# Altium schematic sheet [00] - Top Sheet.SchDoc
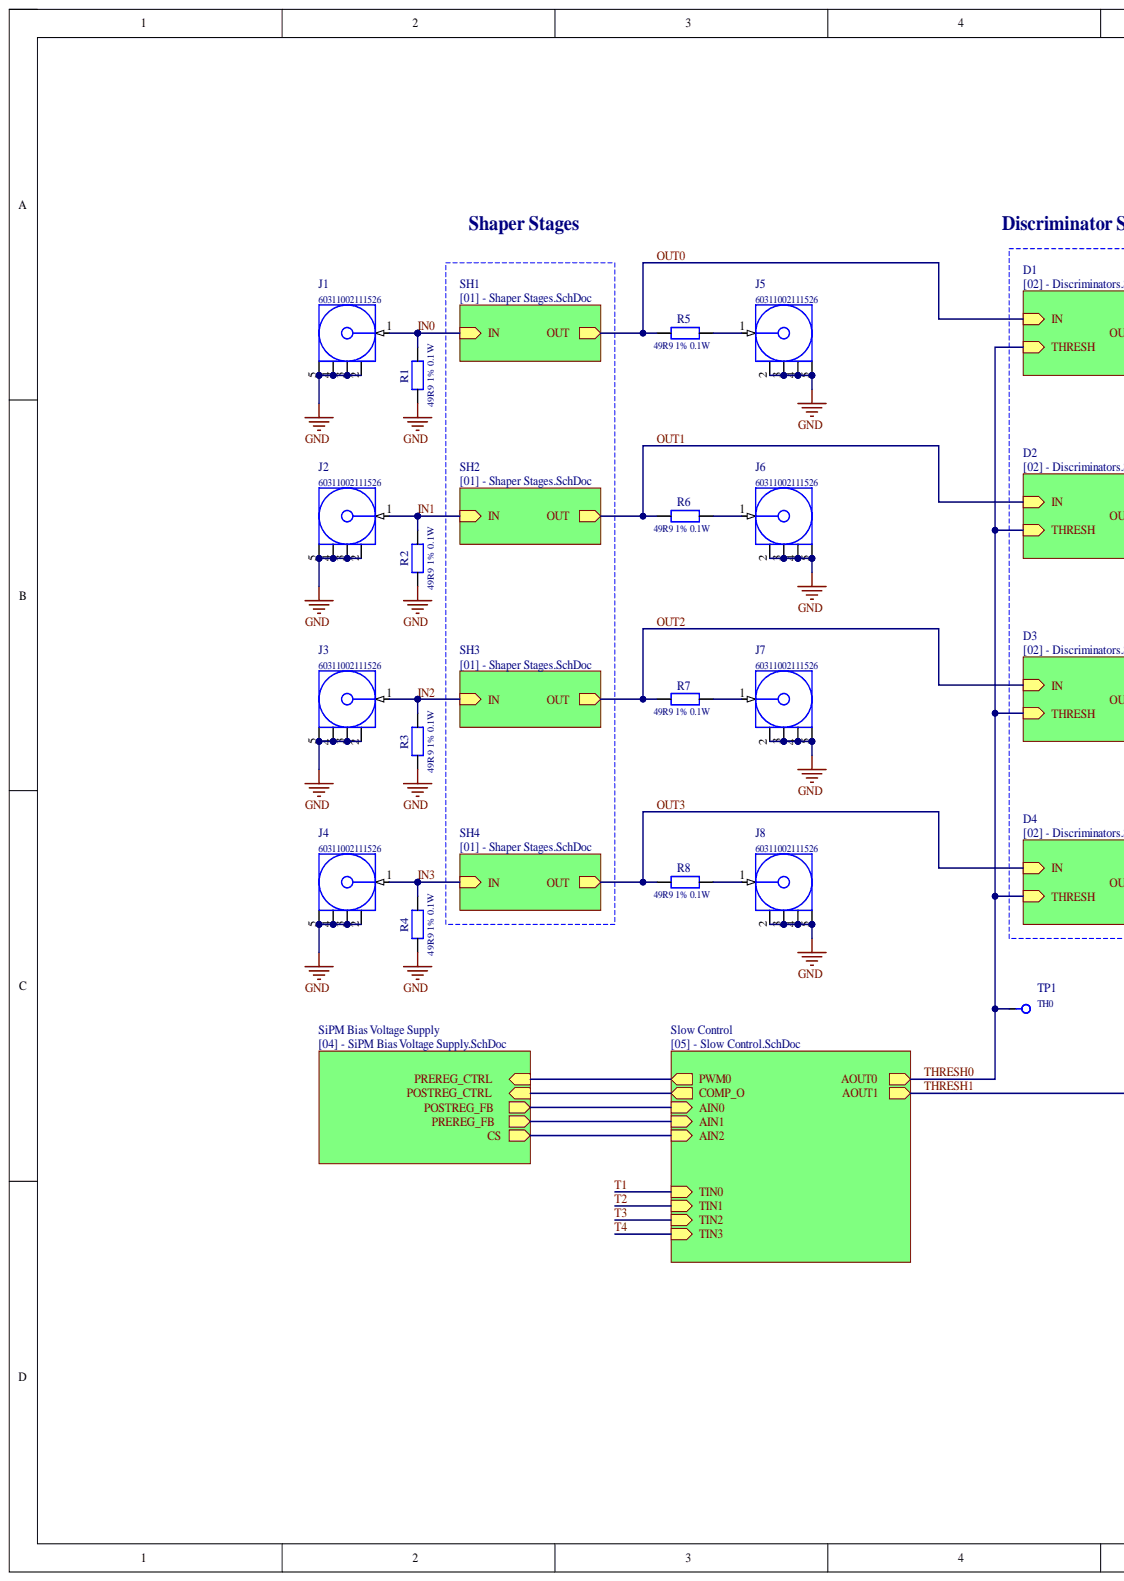
[diagram p0: [00] - Top Sheet.SchDoc, left]
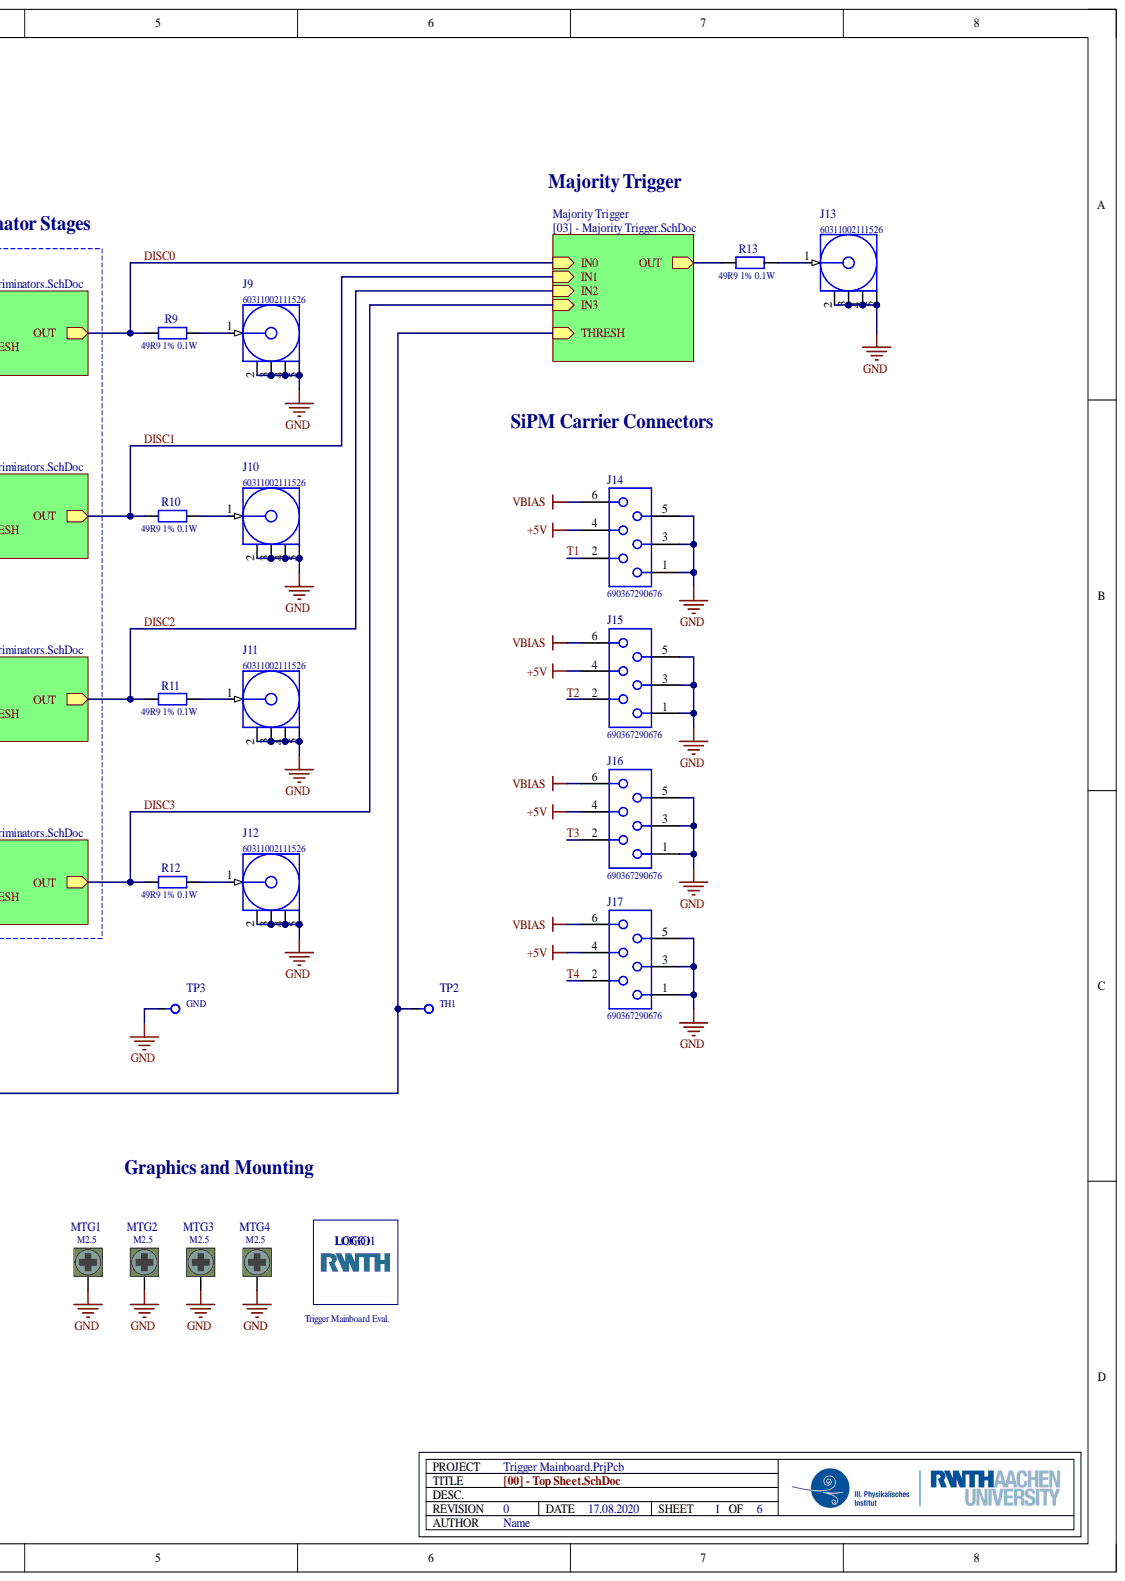
[diagram p1: [00] - Top Sheet.SchDoc, right]

## Parts
J1  60311002111526  [60311002111526]
J2  60311002111526  [60311002111526]
J3  60311002111526  [60311002111526]
J4  60311002111526  [60311002111526]
J5  60311002111526  [60311002111526]
J6  60311002111526  [60311002111526]
J7  60311002111526  [60311002111526]
J8  60311002111526  [60311002111526]
J9  60311002111526  [60311002111526]
J10  60311002111526  [60311002111526]
J11  60311002111526  [60311002111526]
J12  60311002111526  [60311002111526]
J13  60311002111526  [60311002111526]
J14  690367290676  [690367290676]
J15  690367290676  [690367290676]
J16  690367290676  [690367290676]
J17  690367290676  [690367290676]
LOGO1  Trigger Mainboard Eval.  [RWTH_LOGO_4x15MM]  (no BOM)
MTG1  M2.5  [Mounting_Hole_M2.5]  (no BOM)
MTG2  M2.5  [Mounting_Hole_M2.5]  (no BOM)
MTG3  M2.5  [Mounting_Hole_M2.5]  (no BOM)
MTG4  M2.5  [Mounting_Hole_M2.5]  (no BOM)
R1  49R9 1% 0.1W  [0603_RES]
R2  49R9 1% 0.1W  [0603_RES]
R3  49R9 1% 0.1W  [0603_RES]
R4  49R9 1% 0.1W  [0603_RES]
R5  49R9 1% 0.1W  [0603_RES]
R6  49R9 1% 0.1W  [0603_RES]
R7  49R9 1% 0.1W  [0603_RES]
R8  49R9 1% 0.1W  [0603_RES]
R9  49R9 1% 0.1W  [0603_RES]
R10  49R9 1% 0.1W  [0603_RES]
R11  49R9 1% 0.1W  [0603_RES]
R12  49R9 1% 0.1W  [0603_RES]
R13  49R9 1% 0.1W  [0603_RES]
TP1  TH0  [Testpoint_5002]
TP2  TH1  [Testpoint_5002]
TP3  GND  [Testpoint_5001]

## Nets
+5V: J14.4 J15.4 J16.4 J17.4
GND: J1.2 J1.3 J1.4 J1.5 J2.2 J2.3 J2.4 J2.5 J3.2 J3.3 J3.4 J3.5 J4.2 J4.3 J4.4 J4.5 J5.2 J5.3 J5.4 J5.5 J6.2 J6.3 J6.4 J6.5 J7.2 J7.3 J7.4 J7.5 J8.2 J8.3 J8.4 J8.5 J9.2 J9.3 J9.4 J9.5 J10.2 J10.3 J10.4 J10.5 J11.2 J11.3 J11.4 J11.5 J12.2 J12.3 J12.4 J12.5 J13.2 J13.3 J13.4 J13.5 J14.1 J14.3 J14.5 J15.1 J15.3 J15.5 J16.1 J16.3 J16.5 J17.1 J17.3 J17.5 MTG1.1 MTG2.1 MTG3.1 MTG4.1 R1.2 R2.2 R3.2 R4.2 TP3.1
VBIAS: J14.6 J15.6 J16.6 J17.6
DISC0: R9.2
DISC1: R10.2
DISC2: R11.2
DISC3: R12.2
IN0: J1.1 R1.1
IN1: J2.1 R2.1
IN2: J3.1 R3.1
IN3: J4.1 R4.1
OUT0: R5.2
OUT1: R6.2
OUT2: R7.2
OUT3: R8.2
T1: J14.2
T2: J15.2
T3: J16.2
T4: J17.2
THRESH0: TP1.1
THRESH1: TP2.1
NetJ5_1: J5.1 R5.1
NetJ6_1: J6.1 R6.1
NetJ7_1: J7.1 R7.1
NetJ8_1: J8.1 R8.1
NetJ9_1: J9.1 R9.1
NetJ10_1: J10.1 R10.1
NetJ11_1: J11.1 R11.1
NetJ12_1: J12.1 R12.1
NetJ13_1: J13.1 R13.1
NetR13_2: R13.2
AIN0:
AIN1:
AIN2:
COMP_O:
PREREG_CTRL:

## Library data of the parts
J1-J13 = 60311002111526: WR-SMA PCB Jack, THT, Right Angle; Manufacturer: Wurth Elektronik; Center Contact Diameter: 1.27mm; Frequency Range: DC~6GHz; Impedance: 50Ohm; Interface: MIL-STD-348; Component Kind: Standard; ComponentLink1Description: Manufacturer; ComponentLink1URL: http://www.we-online.de; ComponentLink2Description: Datasheet; ComponentLink2URL: https://katalog.we-online.com/em/datasheet/60311002111526.pdf; Gender: Jack; Library Name: WR-SMA.IntLib; Mounting Technology: THT; Operating Temperature: -65 to +165degC; Orientation Type: Right Angle; PackageReference: SMA; Packaging: Tray; PartNumber: 60311002111526; Published Date: May 2019
J14-J17 = 690367290676: WR-MM MiniModule Connector, Female, SMT, Vertical, pitch 2.54mm, 6pins; Manufacturer: Wurth Elektronik; Contact Resistance: 10mOhm; Insulation Resistance: >1000MOhm; Pin Number: 6; Rated Current: 1.5A; Withstanding Voltage: 500VAC; Working Voltage: 100VAC; Component Kind: Standard; ComponentLink1Description: Manufacturer; ComponentLink1URL: http://www.we-online.de; ComponentLink2Description: Datasheet; ComponentLink2URL: https://katalog.we-online.de/em/datasheet/690367290676.pdf; Gender: Female; Library Name: WR-MM.IntLib; Mounting Technology: SMT; Operating Temperature: -40 to +105degC; Orientation: Vertical; PackageReference: WR-MM; Packaging: Tape and Reel; PartNumber: 690367290676; Pitch: 2.54mm; Published Date: June 2019
LOGO1 = Logo: Logo Spaceholder.; Manufacturer: RWTH; Library Name: CustomComponents.IntLib; Value: Trigger Mainboard Eval.
MTG1-MTG4 = Mounting_Hole: Simple mounting hole.; Library Name: CustomComponents.IntLib; Value: M2.5
R1-R13 = Resistor: Simple generic resistor.; Component Kind: Standard; Library Name: CustomComponents.IntLib; PackageReference: 0603; Value: 49R9 1% 0.1W
TP1-TP3 = Testpoint: Red PC Test Point, Miniature Phosphor Bronze, Silver Plating 0.040" (1.02mm) Hole Diameter Mounting Type.; Manufacturer: Keystone Electronics; PartNumber: 500X; Component Kind: Standard; Library Name: CustomComponents.IntLib; PackageReference: Test Point
  TP1: Value: TH0
  TP2: Value: TH1
  TP3: Value: GND
